# Altium schematic sheet Ethernet.SchDoc
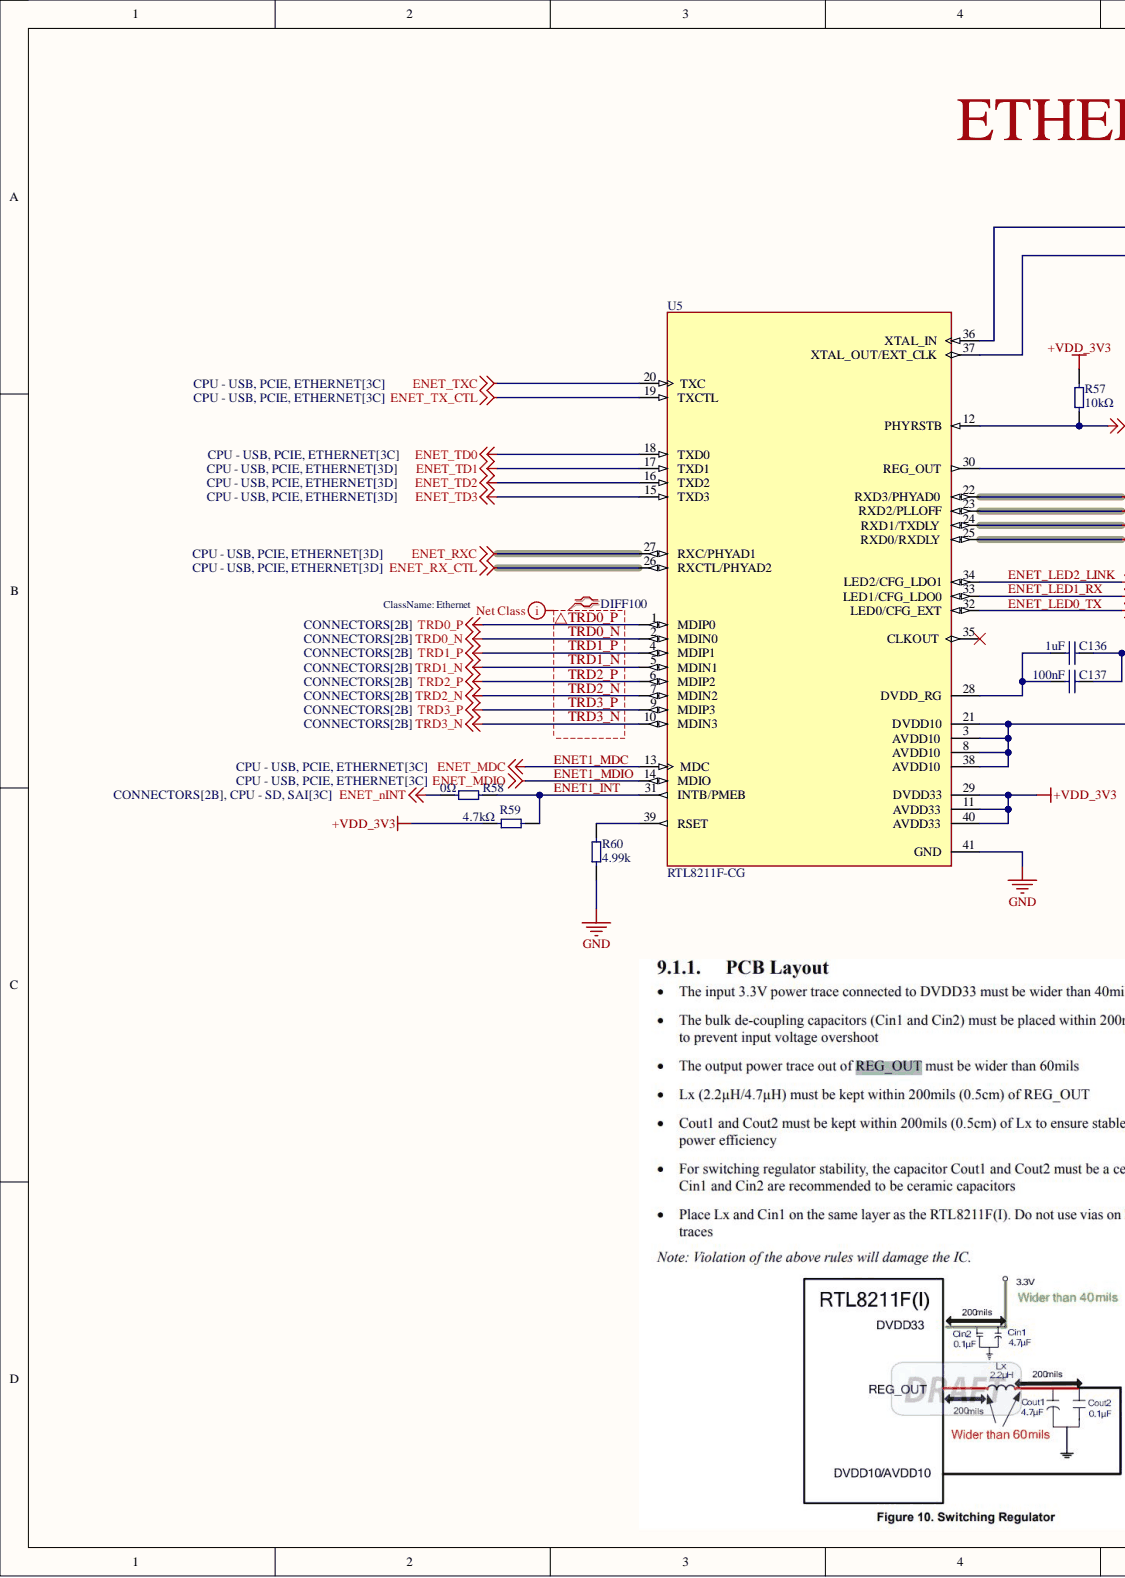
[diagram p0: Ethernet.SchDoc, left]
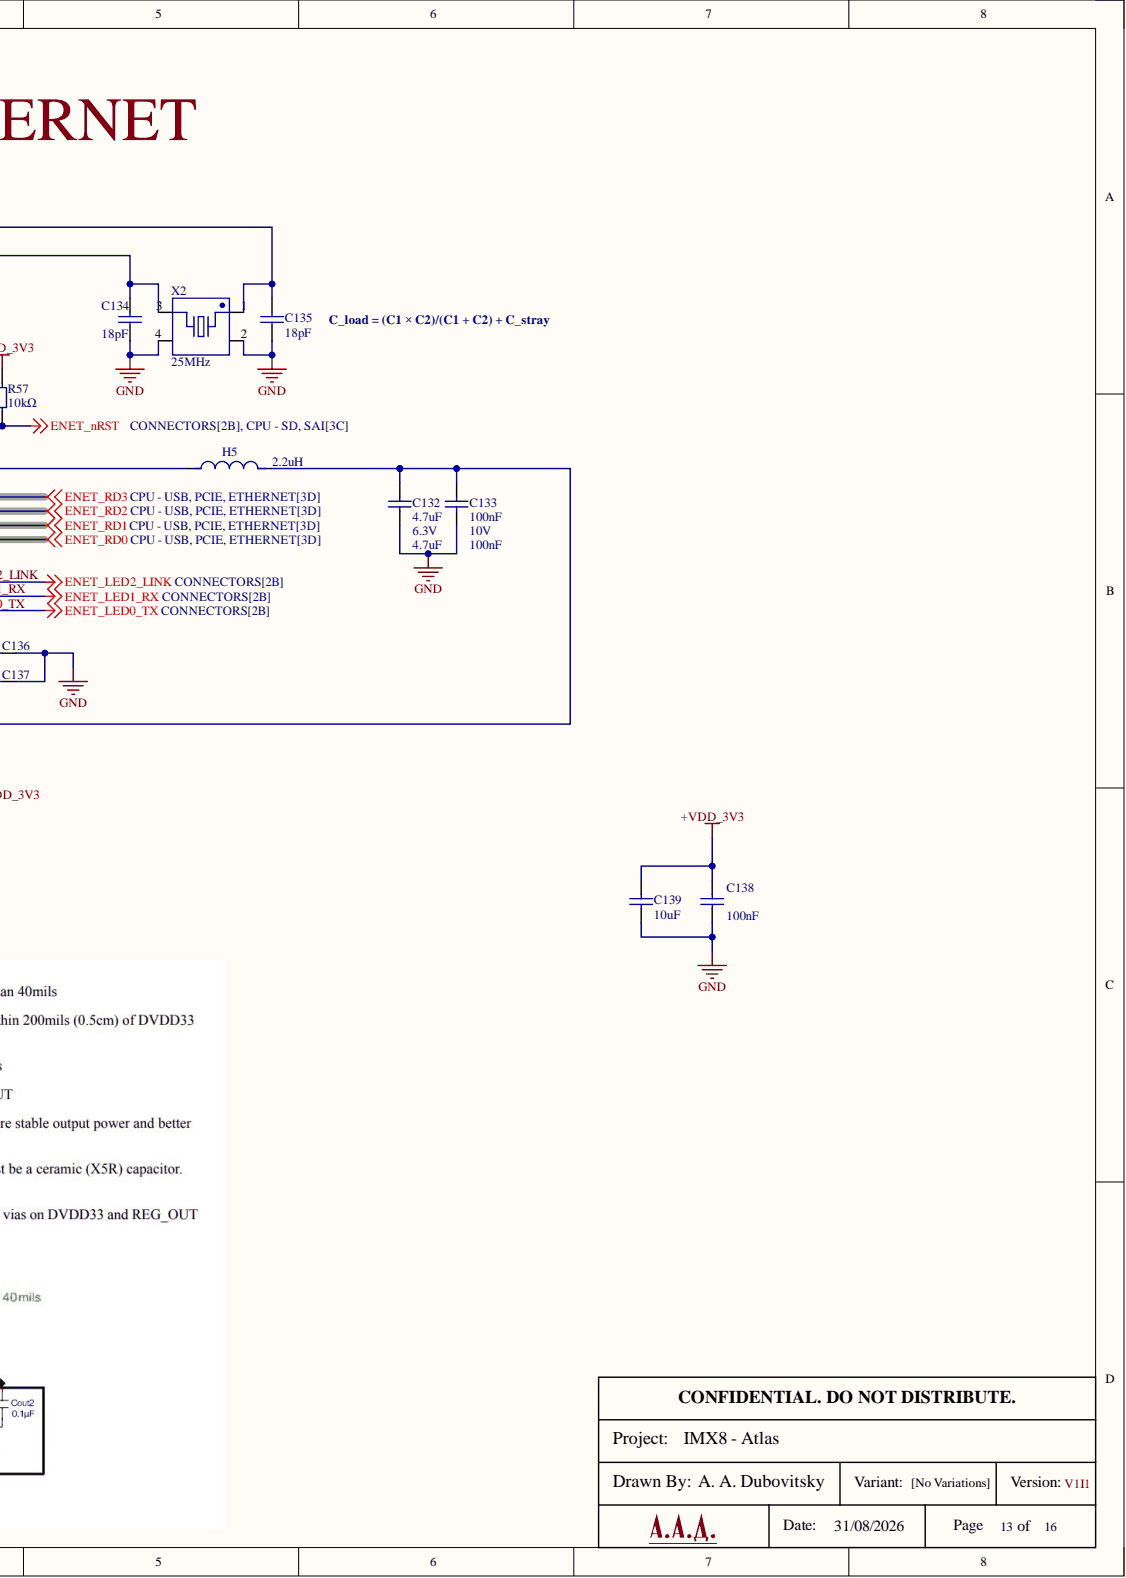
[diagram p1: Ethernet.SchDoc, right]

## Parts
C132  4.7uF  V: 6.3V  [CAP 0402_1005]
C133  100nF  V: 10V  [CAP 0201_0603 MINI]
C134  18pF  [CAP 0402_1005]
C135  18pF  [CAP 0402_1005]
C136  1uF  [CAP 0201_0603 MINI]
C137  100nF  [CAP 0201_0603 MINI]
C138  100nF  [CAP 0201_0603 MINI]
C139  10uF  [CAP 0402_1005]
H5  2.2uH  [IND-SMD_L2.0-W1.6_FNR2016XXS]
R57  10kΩ  [RES 0201_0603]
R58  0Ω  [RES 0201_0603]
R59  4.7kΩ  [RES 0201_0603]
R60  4.99k  [RES 0402_1005]
U5  RTL8211F-CG  [QFN40P500X500X90-41N]
X2  25MHz  [CRYSTAL-SMD_4P-L3.2-W2.5-BL]

## Nets
+VDD_3V3: C138.2 C139.2 R57.2 R59.2 U5.11(AVDD33) U5.29(DVDD33) U5.40(AVDD33)
ENET_nINT: R58.2
ENET_nRST: R57.1 U5.12(PHYRSTB)
ENET_RD0: U5.25(RXD0/RXDLY)
ENET_RD1: U5.24(RXD1/TXDLY)
ENET_RD2: U5.23(RXD2/PLLOFF)
ENET_RD3: U5.22(RXD3/PHYAD0)
ENET_RX_CTL: U5.26(RXCTL/PHYAD2)
ENET_RXC: U5.27(RXC/PHYAD1)
ENET_TD0: U5.18(TXD0)
ENET_TD1: U5.17(TXD1)
ENET_TD2: U5.16(TXD2)
ENET_TD3: U5.15(TXD3)
ENET_TX_CTL: U5.19(TXCTL)
ENET_TXC: U5.20(TXC)
GND: C132.1 C133.1 C134.1 C135.1 C136.2 C137.1 C138.1 C139.1 R60.2 U5.41(GND) X2.2 X2.4
ENET1_INT: R58.1 R59.1 U5.31(INTB/PMEB)
ENET1_MDC: U5.13(MDC)
ENET1_MDIO: U5.14(MDIO)
ENET_LED0_TX: U5.32(LED0/CFG_EXT)
ENET_LED1_RX: U5.33(LED1/CFG_LDO0)
ENET_LED2_LINK: U5.34(LED2/CFG_LDO1)
TRD0_N: U5.2(MDIN0)
TRD0_P: U5.1(MDIP0)
TRD1_N: U5.5(MDIN1)
TRD1_P: U5.4(MDIP1)
TRD2_N: U5.7(MDIN2)
TRD2_P: U5.6(MDIP2)
TRD3_N: U5.10(MDIN3)
TRD3_P: U5.9(MDIP3)
NetC132_2: C132.2 C133.2 H5.2 U5.3(AVDD10) U5.8(AVDD10) U5.21(DVDD10) U5.38(AVDD10)
NetC134_2: C134.2 U5.37(XTAL_OUT/EXT_CLK) X2.3
NetC135_2: C135.2 U5.36(XTAL_IN) X2.1
NetC136_1: C136.1 C137.2 U5.28(DVDD_RG)
NetH5_1: H5.1 U5.30(REG_OUT)
NetR60_1: R60.1 U5.39(RSET)

Not connected: U5 35(CLKOUT)

## Library data of the parts
C132 = GRM155R60J475ME87D: Cap. 4.7uF 6.3V ±20%; C: 4.7uF; Component Kind: Standard; Library Name: Atlas - Symbols.SchLib
C133 C137 C138 = GRM033R61A104KE15D: Cap. 100nF 10V ±10%; C: 100nF; Component Kind: Standard; Library Name: Atlas - Symbols.SchLib
  C137 C138: V: 10V
C134 C135 = 0402CG180J500NT: Cap.  18pF 50V ±5%; Component Kind: Standard; Parameter 1: 18pF; Library Name: Atlas - Symbols.SchLib
C136 = C6119758: Cap. 1uF 10V ±20% 0201; Component Kind: Standard; Library Name: Atlas - Symbols.SchLib
C139 = C2846677: Cap. 10uF 10V ±20% 0402; Component Kind: Standard; Library Name: Atlas - Symbols.SchLib
H5 = C315717: Ind. 1.7A 135mΩ 2.2uH ±20% 0806; Component Kind: Standard; Library Name: Atlas - Symbols.SchLib
R57 = C138117: Res. 10kΩ 25V 50mW ±5% 0201; Component Kind: Standard; Library Name: Atlas - Symbols.SchLib
R58 = C106227: Res. 0Ω 25V 50mW ±1% 0201; Component Kind: Standard; Library Name: Atlas - Symbols.SchLib
R59 = C3000902: Res. 4.7kΩ 30V 50mW ±1% 0201; Component Kind: Standard; Library Name: Atlas - Symbols.SchLib
R60 = C852823: Res. 4.99kΩ 62.5mW ±0.1%  0402; Component Kind: Standard; Library Name: Atlas - Symbols.SchLib
U5 = RTL8211F-CG: GbE PHY with RGMIII interface & EEE feature (Switch Regulator), QFN40; MF: Realtek Semiconductor Corp; Check_prices: https://www.snapeda.com/parts/RTL8211F-CG/Realtek/view-part/?ref=eda; Component Kind: Standard; MP: RTL8211F-CG; Package: None; SnapEDA_Link: https://www.snapeda.com/parts/RTL8211F-CG/Realtek/view-part/?ref=snap; Library Name: Atlas - Symbols.SchLib
X2 = C9006: Crystal Oscillator 25MHz 12pF; Component Kind: Standard; Library Name: Atlas - Symbols.SchLib
